# Altium schematic sheet main.SchDoc
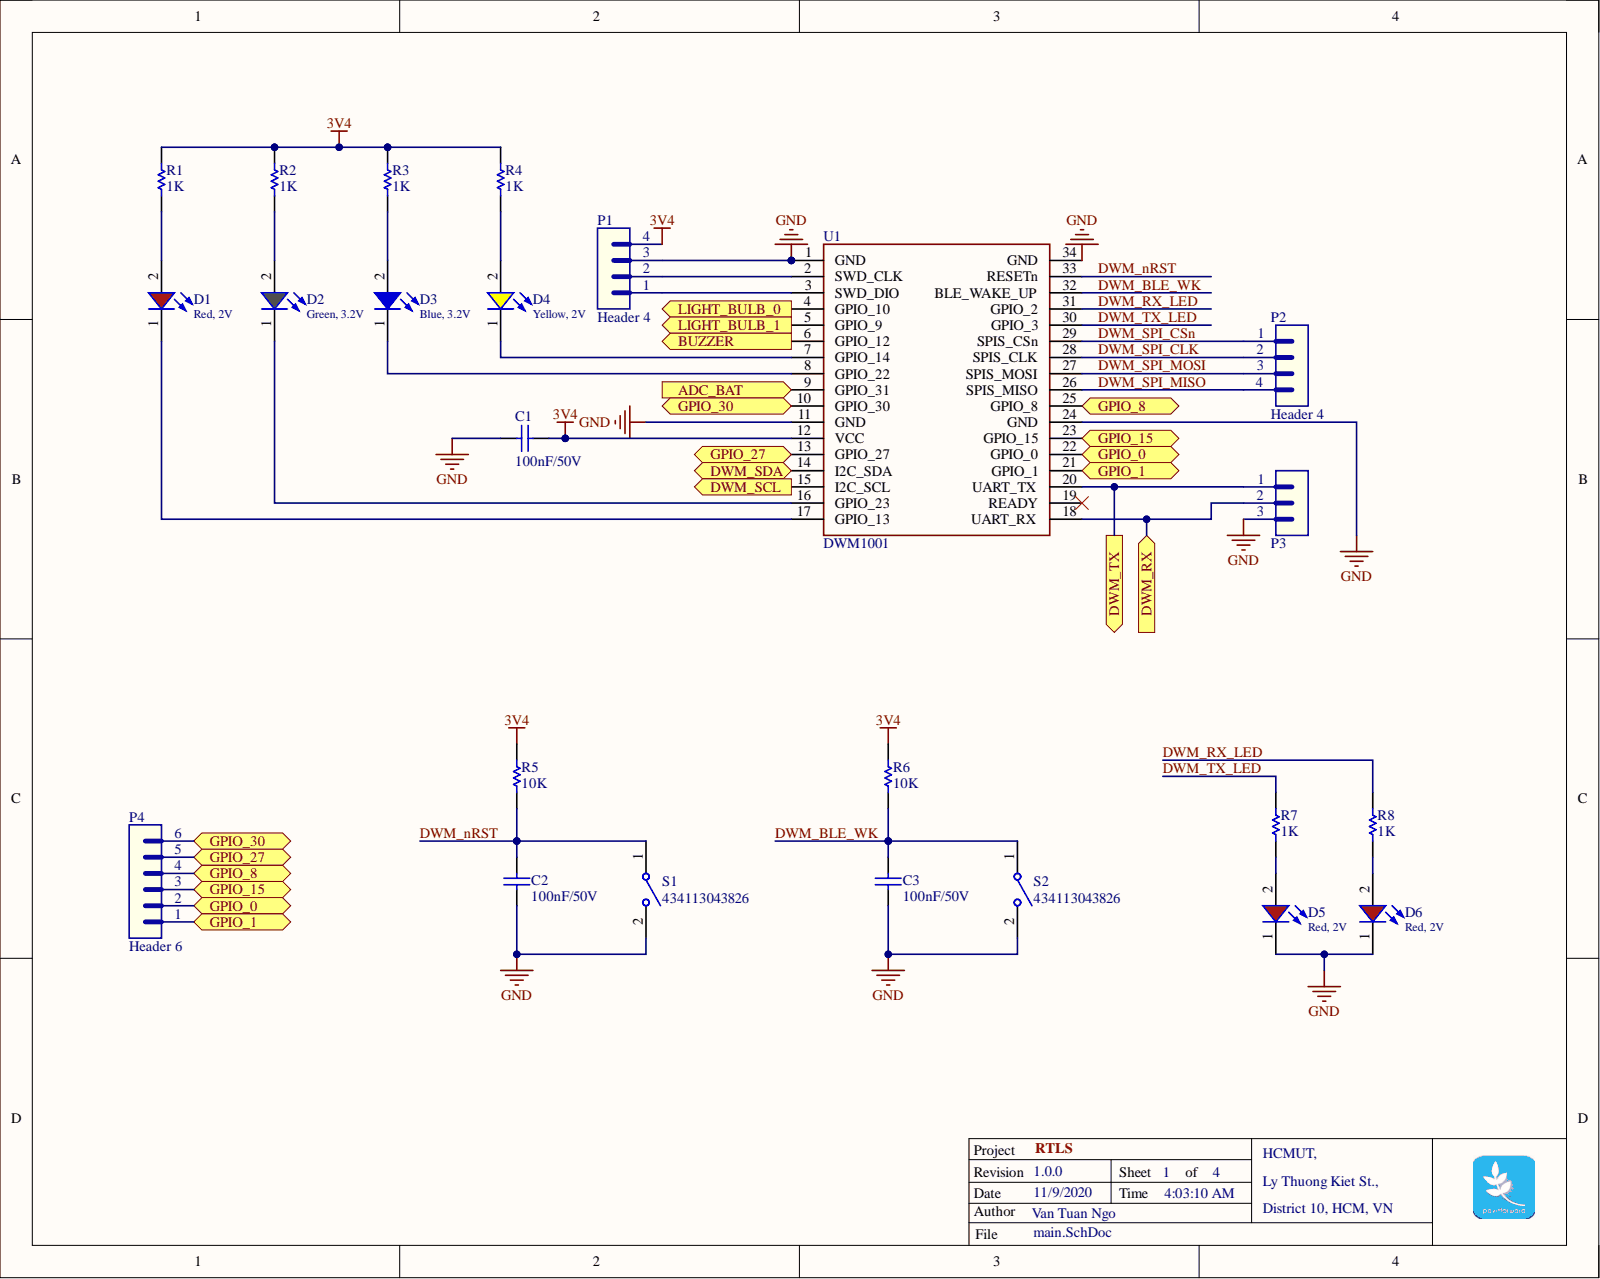
[diagram p0: main.SchDoc]

## Parts
C1  value 100nF/50V  [CAP-SM-0805]  (hidden comment: Cap-100nF-50V-0805)
C2  value 100nF/50V  [CAP-SM-0805]  (hidden comment: Cap-100nF-50V-0805)
C3  value 100nF/50V  [CAP-SM-0805]  (hidden comment: Cap-100nF-50V-0805)
D1  Red, 2V  [WL-SMCW_0805]
D2  Green, 3.2V  [WL-SMCW_0805]
D3  Blue, 3.2V  Forward Voltage typ.: 3.2V  [WL-SMCW_0805]
D4  Yellow, 2V  [WL-SMCW_0805]
D5  Red, 2V  [WL-SMCW_0805]
D6  Red, 2V  Forward Voltage typ.: 2V  [WL-SMCW_0805]
P1  Header 4  [JP4]
P2  Header 4  [JP4]
P3  Header 3  [JP3]  (value not drawn)
P4  Header 6  [JP6]
R1  value 1K  [RES-SM-0805]  (hidden comment: Res-1K-0805)
R2  value 1K  [RES-SM-0805]  (hidden comment: Res-1K-0805)
R3  value 1K  [RES-SM-0805]  (hidden comment: Res-1K-0805)
R4  value 1K  [RES-SM-0805]  (hidden comment: Res-1K-0805)
R5  value 10K  [RES-SM-0805]  (hidden comment: Res-10k-0805)
R6  value 10K  [RES-SM-0805]  (hidden comment: Res-10k-0805)
R7  value 1K  [RES-SM-0805]  (hidden comment: Res-1K-0805)
R8  value 1K  [RES-SM-0805]  (hidden comment: Res-1K-0805)
S1  434113043826  [434113043826]
S2  434113043826  [434113043826]
U1  DWM1001  [DWM1001]

## Ports
ADC_BAT, BUZZER, DWM_RX, DWM_SCL, DWM_SDA, DWM_TX, GPIO_0, GPIO_1, GPIO_8, GPIO_15, GPIO_27, GPIO_30, LIGHT_BULB_0, LIGHT_BULB_1

## Nets
3V4: C1.2 P1.4 R1.1 R2.1 R3.1 R4.1 R5.2 R6.2 U1.12(VCC)
GND: C1.1 C2.1 C3.1 D5.1 D6.1 P1.3 P3.3 S1.2 S2.2 U1.1(GND) U1.11(GND) U1.24(GND) U1.34(GND)
ADC_BAT: U1.9(GPIO_31) ; port ADC_BAT
BUZZER: U1.6(GPIO_12) ; port BUZZER
DWM_BLE_WK: C3.2 R6.1 S2.1 U1.32(BLE_WAKE_UP)
DWM_nRST: C2.2 R5.1 S1.1 U1.33(RESETn)
DWM_RX: P3.2 U1.18(UART_RX) ; port DWM_RX
DWM_RX_LED: R8.1 U1.31(GPIO_2)
DWM_SCL: U1.15(I2C_SCL) ; port DWM_SCL
DWM_SDA: U1.14(I2C_SDA) ; port DWM_SDA
DWM_SPI_CLK: P2.2 U1.28(SPIS_CLK)
DWM_SPI_CSn: P2.1 U1.29(SPIS_CSn)
DWM_SPI_MISO: P2.4 U1.26(SPIS_MISO)
DWM_SPI_MOSI: P2.3 U1.27(SPIS_MOSI)
DWM_TX: P3.1 U1.20(UART_TX) ; port DWM_TX
DWM_TX_LED: R7.1 U1.30(GPIO_3)
GPIO_0: P4.2 U1.22(GPIO_0) ; port GPIO_0
GPIO_1: P4.1 U1.21(GPIO_1) ; port GPIO_1
GPIO_8: P4.4 U1.25(GPIO_8) ; port GPIO_8
GPIO_15: P4.3 U1.23(GPIO_15) ; port GPIO_15
GPIO_27: P4.5 U1.13(GPIO_27) ; port GPIO_27
GPIO_30: P4.6 U1.10(GPIO_30) ; port GPIO_30
LIGHT_BULB_0: U1.4(GPIO_10) ; port LIGHT_BULB_0
LIGHT_BULB_1: U1.5(GPIO_9) ; port LIGHT_BULB_1
NetD1_1: D1.1 U1.17(GPIO_13)
NetD1_2: D1.2 R1.2
NetD2_1: D2.1 U1.16(GPIO_23)
NetD2_2: D2.2 R2.2
NetD3_1: D3.1 U1.8(GPIO_22)
NetD3_2: D3.2 R3.2
NetD4_1: D4.1 U1.7(GPIO_14)
NetD4_2: D4.2 R4.2
NetD5_2: D5.2 R7.2
NetD6_2: D6.2 R8.2
NetP1_1: P1.1 U1.3(SWD_DIO)
NetP1_2: P1.2 U1.2(SWD_CLK)

Not connected: U1 19(READY)

## Library data of the parts
C1-C3 = Cap-100nF-50V-0805: CAP CER 0.1UF 50V Y5V 0805; Manufacturer: Samsung Electro-Mechanics; Manufacturer Part Number: CL21F104ZBCNNNC; Component Kind: Standard; Library Name: capacitor-smd.SchLib; Local Supplier: thegioiic; Local Supplier Link: https://www.thegioiic.com/products/tu-gom-0805-100nf-0-1uf-50v; Supplier 1: Digikey; Supplier 1 Link: https://www.digikey.com/product-detail/en/samsung-electro-mechanics/CL21F104ZBCNNNC/1276-1007-1-ND/3889093; Supplier 1 Part Number: 1276-1007-1-ND
D1 D5 D6 = 150080RS75000: WL-SMCW SMT Mono-color Chip LED Waterclear, size 0805, Red, 2V, 140deg; Chip Technology: AlInGaP; Dominant Wavelength typ.: 625nm; Luminous Intensity typ.: 150mcd; Peak Wavelength typ.: 630nm; Component Kind: Standard; ComponentLink1Description: Manufacturer; ComponentLink1URL: http://www.we-online.de; ComponentLink2Description: Datasheet; ComponentLink2URL: https://www.we-online.com/catalog/datasheet/150080RS75000.pdf; Emitting Color: Red; Library Name: led-smd-0805.SchLib; Mounting Technology: SMT; Operating Temperature: -40 to +85degC; Packaging: Tape and Reel; Part Number: 150080RS75000; Published Date: December 2019; Size: 0805; Viewing Angle: 140deg; Publisher: Wurth Elektronik
  D1 D5: Forward Voltage typ.: 2V
D2 = 150080GS75000: WL-SMCW SMT Mono-color Chip LED Waterclear, size 0805, Green, 3.2V, 140deg; Chip Technology: InGaN; Dominant Wavelength typ.: 525nm; Luminous Intensity typ.: 450mcd; Peak Wavelength typ.: 515nm; Component Kind: Standard; ComponentLink1Description: Manufacturer; ComponentLink1URL: http://www.we-online.de; ComponentLink2Description: Datasheet; ComponentLink2URL: https://www.we-online.com/catalog/datasheet/150080GS75000.pdf; Emitting Color: Green; Forward Voltage typ.: 3.2V; Library Name: led-smd-0805.SchLib; Mounting Technology: SMT; Operating Temperature: -40 to +85degC; Packaging: Tape and Reel; Part Number: 150080GS75000; Published Date: December 2019; Size: 0805; Viewing Angle: 140deg; Publisher: Wurth Elektronik
D3 = 150080BS75000: WL-SMCW SMT Mono-color Chip LED Waterclear, size 0805, Blue, 3.2V, 140deg; Chip Technology: InGaN; Dominant Wavelength typ.: 470nm; Luminous Intensity typ.: 145mcd; Peak Wavelength typ.: 465nm; Component Kind: Standard; ComponentLink1Description: Manufacturer; ComponentLink1URL: http://www.we-online.de; ComponentLink2Description: Datasheet; ComponentLink2URL: https://www.we-online.com/catalog/datasheet/150080BS75000.pdf; Emitting Color: Blue; Library Name: led-smd-0805.SchLib; Mounting Technology: SMT; Operating Temperature: -40 to +85degC; Packaging: Tape and Reel; Part Number: 150080BS75000; Published Date: December 2019; Size: 0805; Viewing Angle: 140deg; Publisher: Wurth Elektronik
D4 = 150080YS75000: WL-SMCW SMT Mono-color Chip LED Waterclear, size 0805, Yellow, 2V, 140deg; Chip Technology: AlInGaP; Dominant Wavelength typ.: 590nm; Luminous Intensity typ.: 120mcd; Peak Wavelength typ.: 595nm; Component Kind: Standard; ComponentLink1Description: Manufacturer; ComponentLink1URL: http://www.we-online.de; ComponentLink2Description: Datasheet; ComponentLink2URL: https://www.we-online.com/catalog/datasheet/150080YS75000.pdf; Emitting Color: Yellow; Forward Voltage typ.: 2V; Library Name: led-smd-0805.SchLib; Mounting Technology: SMT; Operating Temperature: -40 to +85degC; Packaging: Tape and Reel; Part Number: 150080YS75000; Published Date: December 2019; Size: 0805; Viewing Angle: 140deg; Publisher: Wurth Elektronik
P1 P2 = Header 4: Header, 4-Pin; Component Kind: Standard; LatestRevisionDate: 17-Jul-2002; LatestRevisionNote: Re-released for DXP Platform.; Library Name: header-connector.SchLib; Local Supplier: thegioiic; Local Supplier Link: https://www.thegioiic.com/products/hang-rao-duc-don-2-54mm-40-chan-1-hang-cao-11-4mm-xuyen-lo; Local Supplier Part Number: x; Publisher: Altium Limited
P3 = Header 3: Header, 3-Pin; Component Kind: Standard; LatestRevisionDate: 17-Jul-2002; LatestRevisionNote: Re-released for DXP Platform.; Library Name: header-connector.SchLib; Local Supplier: thegioiic; Local Supplier Link: https://www.thegioiic.com/products/hang-rao-duc-don-2-54mm-40-chan-1-hang-cao-11-4mm-xuyen-lo; Local Supplier Part Number: x; Publisher: Altium Limited
P4 = Header 6: Header, 6-Pin; Component Kind: Standard; LatestRevisionDate: 17-Jul-2002; LatestRevisionNote: Re-released for DXP Platform.; Library Name: header-connector.SchLib; Local Supplier: thegioiic; Local Supplier Link: https://www.thegioiic.com/products/hang-rao-duc-don-2-54mm-40-chan-1-hang-cao-11-4mm-xuyen-lo; Local Supplier Part Number: x; Publisher: Altium Limited
R1-R4 R7 R8 = Res-1K-0805: RES 1K OHM 1% 1/4W 0805; Manufacturer: Stackpole Electronics Inc; Manufacturer Part Number: RNCP0805FTD1K00; Component Kind: Standard; Library Name: resistor-smd.SchLib; Local Supplier: thegioiic; Local Supplier Link: https://www.thegioiic.com/products/dien-tro-1-kohm-0805-5; Supplier 1: Digikey; Supplier 1 Link: https://www.digikey.com/product-detail/en/stackpole-electronics-inc/RNCP0805FTD1K00/RNCP0805FTD1K00CT-ND/2240568; Supplier 1 Part Number: RNCP0805FTD1K00CT-ND
R5 R6 = Res-10k-0805: RES 10K OHM 1% 1/4W 0805; Manufacturer: Stackpole Electronics Inc; Manufacturer Part Number: RNCP0805FTD10K0; Component Kind: Standard; Library Name: resistor-smd.SchLib; Local Supplier: thegioiic; Local Supplier Link: https://www.thegioiic.com/products/dien-tro-10-kohm-0805-5; Supplier 1: Digikey; Supplier 1 Link: https://www.digikey.com/product-detail/en/stackpole-electronics-inc/RNCP0805FTD10K0/RNCP0805FTD10K0CT-ND/2240601; Supplier 1 Part Number: RNCP0805FTD10K0CT-ND
S1 S2 = 434113043826: WS-TASV J-Bend SMT Tact Switch 6x3.5mm, height 4.3mm, 260gf; Rated Current: 50mA; Rated Voltage: 12VDC; Washable: No; Component Kind: Standard; ComponentLink1Description: Manufacturer; ComponentLink1URL: http://www.we-online.de; ComponentLink2Description: Datasheet; ComponentLink2URL: https://katalog.we-online.com/em/datasheet/434113043826.pdf; Contact Material: Stainless Steel; Contact Plating: Silver; Height: 4.3mm; Library Name: switch.SchLib; Local Supplier: thegioiic; Local Supplier Link: https://www.thegioiic.com/products/nut-nhan-3-5x6mm-cao-5mm-2-chan-smd-dau-trang; Local Supplier Part Number: x; Mounting Technology: SMT; Operating Temperature: -40 to +85degC; Operation Force: 260gf; Packaging: Tape and Reel; Part Number: 434113043826; Published Date: September 2019; Terminal Material: Copper Alloy; Terminal Plating: Silver; Publisher: Wurth Elektronik
U1 = DWM1001: Component Kind: Standard; Library Name: module.SchLib; Local Supplier: x; Local Supplier Link: x; Local Supplier Part Number: x
